# Altium schematic sheet LAN8720.SchDoc
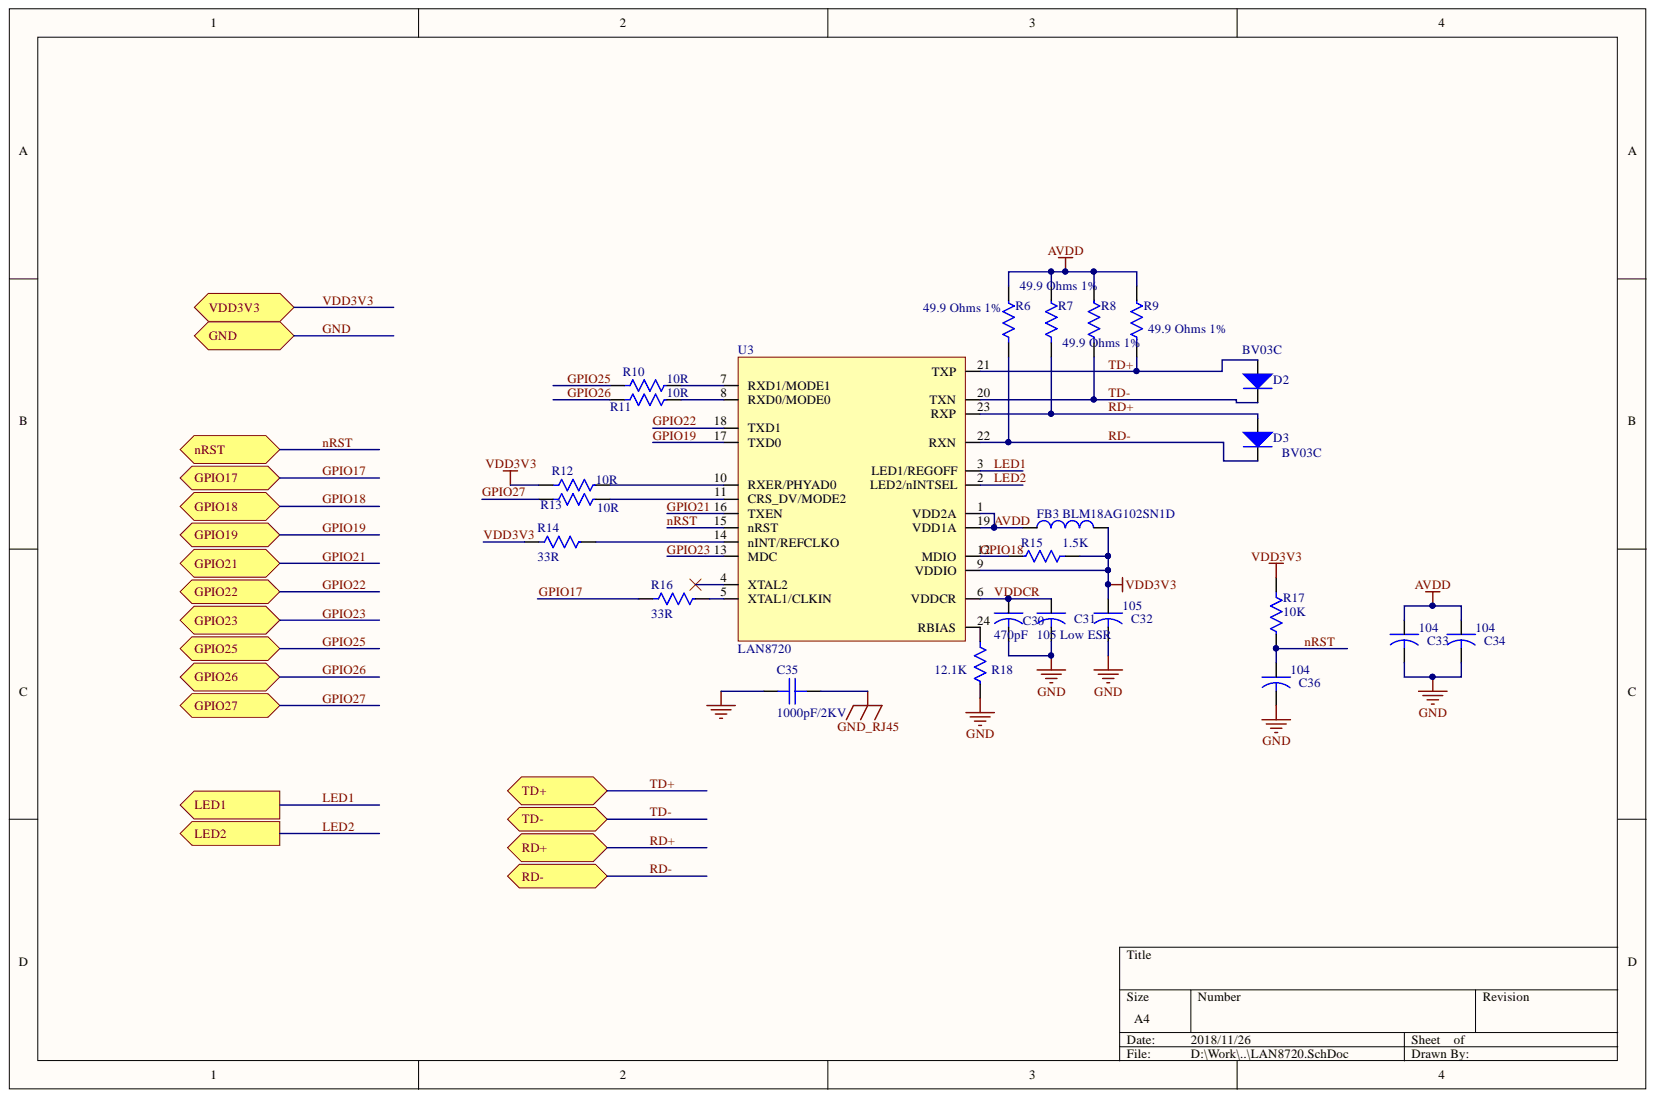
[diagram p0: LAN8720.SchDoc]

## Parts
C30  470pF  [CC0402]
C31  105 Low ESR  [CC0402]
C32  105  [CC0402]
C33  104  [CC0402]
C34  104  [CC0402]
C35  1000pF/2KV  [EIA3216]
C36  104  [CC0402]
D2  BV03C  [DIODE-1206]
D3  BV03C  [DIODE-1206]
FB3  BLM18AG102SN1D  [IND_CB2518_MM]
R6  49.9 Ohms 1%  [CC0805]
R7  49.9 Ohms 1%  [CC0805]
R8  49.9 Ohms 1%  [CC0805]
R9  49.9 Ohms 1%  [CC0805]
R10  10R  [CC0805]
R11  10R  [CC0805]
R12  10R  [CC0805]
R13  10R  [CC0805]
R14  33R  [CC0805]
R15  1.5K  [CC0805]
R16  33R  [CC0805]
R17  10K  [CC0805]
R18  12.1K  [CC0805]
U3  LAN8720  [LGA12R50P4X4_200X200X100]

## Ports
GND, GPIO17, GPIO18, GPIO19, GPIO21, GPIO22, GPIO23, GPIO25, GPIO26, GPIO27, LED1, LED2, NRST, RD+, RD-, TD+, TD-, VDD3V3

## Nets
GND_RJ45: C35.2
AVDD: C33.1 C34.1 FB3.1 R6.2 R7.2 R8.2 R9.2 U3.1(VDD2A) U3.19(VDD1A)
GND: C30.2 C31.2 C32.2 C33.2 C34.2 C35.1 C36.2 R18.2 ; port GND
GPIO17: R16.1 ; port GPIO17
GPIO18: R15.2 U3.12(MDIO) ; port GPIO18
GPIO19: U3.17(TXD0) ; port GPIO19
GPIO21: U3.16(TXEN) ; port GPIO21
GPIO22: U3.18(TXD1) ; port GPIO22
GPIO23: U3.13(MDC) ; port GPIO23
GPIO25: R10.1 ; port GPIO25
GPIO26: R11.1 ; port GPIO26
GPIO27: R13.1 ; port GPIO27
LED1: U3.3(LED1/REGOFF) ; port LED1
LED2: U3.2(LED2/nINTSEL) ; port LED2
NRST: C36.1 R17.2 U3.15(nRST) ; port NRST
RD+: D3.1 R7.1 U3.23(RXP) ; port RD+
RD-: D3.2 R6.1 U3.22(RXN) ; port RD-
TD+: D2.1 R9.1 U3.21(TXP) ; port TD+
TD-: D2.2 R8.1 U3.20(TXN) ; port TD-
VDD3V3: C32.1 FB3.2 R12.1 R14.1 R15.1 R17.1 U3.9(VDDIO) ; port VDD3V3
VDDCR: C30.1 C31.1 U3.6(VDDCR)
NetR10_2: R10.2 U3.7(RXD1/MODE1)
NetR11_2: R11.2 U3.8(RXD0/MODE0)
NetR12_2: R12.2 U3.10(RXER/PHYAD0)
NetR13_2: R13.2 U3.11(CRS_DV/MODE2)
NetR14_2: R14.2 U3.14(nINT/REFCLKO)
NetR16_2: R16.2 U3.5(XTAL1/CLKIN)
NetR18_1: R18.1 U3.24(RBIAS)

Not connected: U3 4(XTAL2)

## Library data of the parts
C30-C34 C36 = C: Component Kind: Standard; Library Name: Wristband Beacon.SCHLIB
C35 = SMT_C_0402: Surface mount capacitor 0402; Component Kind: Standard; Library Name: Vowstar_Basic_Device.IntLib
D2 D3 = TVS: TVS保护; Type: E6.8CA; Code_JEDEC: DO-214-AB; Component Kind: Standard; LatestRevisionDate: 17-Jul-2002; LatestRevisionNote: Re-released for DXP Platform.; PackageDocument: Sep-1996; PackageReference: SMC; Published: 8-Jun-2000; Library Name: fszhang.SchLib; Publisher: Altium Limited
FB3 = INDUCTOR: Component Kind: Standard; Library Name: Wristband Beacon.SCHLIB
R6-R18 = RESISTOR: Component Kind: Standard; Library Name: Wristband Beacon.SCHLIB
U3 = LAN8720: Component Kind: Standard; Library Name: Wristband Beacon.SCHLIB
